# Altium schematic sheet ProjectLOOM.SchDoc(ProjectLOOM)
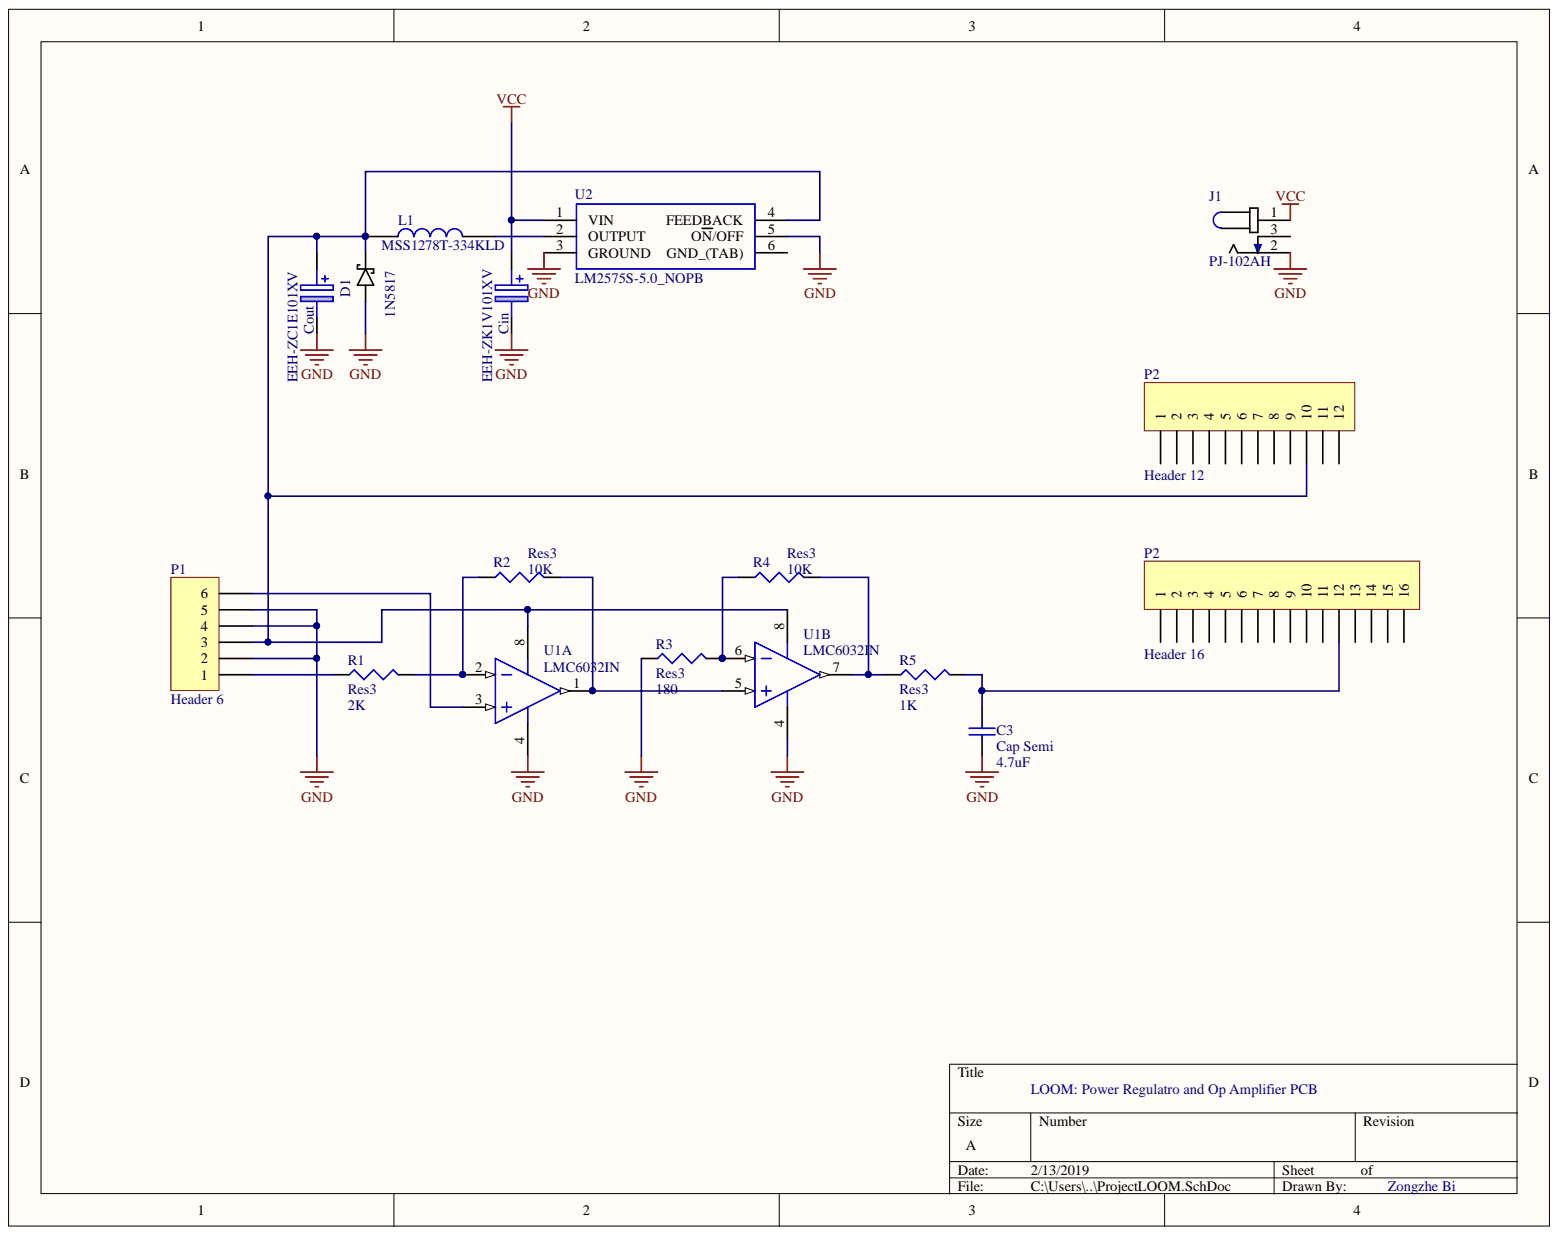
[diagram p0: ProjectLOOM.SchDoc]

## Parts
C3  Cap Semi  value 4.7uF  [C1206]
Cin  EEH-ZK1V101XV  [CAPAE660X800N]
Cout  EEH-ZC1E101XV  [CAPAE660X800N]
D1  1N5817  [DO41-1_1N5817(Primary)]
J1  PJ-102AH  [CUI_PJ-102AH]
L1  MSS1278T-334KLD  [INDPM120120X805N]
P1  Header 6  [HDR1X6]
P2  Header 12  [HDR1X12]
P2  Header 16  [HDR1X16]
R1  Res3  value 2K  [J1-0603]
R2  Res3  value 10K  [J1-0603]
R3  Res3  value 180  [J1-0603]
R4  Res3  value 10K  [J1-0603]
R5  Res3  value 1K  [J1-0603]
U1  LMC6032IN  [N08E]  (parts A,B)
U2  LM2575S-5.0_NOPB  [TO170P1435X465-6N]

## Nets
GND: C3.1 Cin.2 Cout.2 D1.A J1.2 P1.2 P1.4 P1.5 R3.1 U1.4 U2.3(GROUND) U2.5(ON/OFF)
VCC: Cin.1 J1.1 U2.1(VIN)
NetC3_2: C3.2 P2.12 R5.2
NetCout_1: Cout.1 D1.C L1.1 P1.3 P2.10 U1.8 U2.4(FEEDBACK)
NetL1_2: L1.2 U2.2(OUTPUT)
NetP1_1: P1.1 R1.1
NetP1_6: P1.6 U1.3
NetR1_2: R1.2 R2.1 U1.2
NetR2_2: R2.2 U1.1 U1.5
NetR3_2: R3.2 R4.1 U1.6
NetR4_2: R4.2 R5.1 U1.7

Not connected: J1 3; P2 1 2 3 4 5 6 7 8 9 11 13 14 15 16; U2 6(GND_(TAB))

## Library data of the parts
C3 = Cap Semi: Capacitor (Semiconductor SIM Model); Simulation: CAP; Signal Integrity: Cap Semi; Published: 8-Jun-2000; PackageReference: C1206; PackageDescription: Chip Capacitor; Library Name: Miscellaneous Devices.IntLib; LatestRevisionNote: IPC-7351 Footprint Added.; LatestRevisionDate: 29-May-2009; Component Kind: Standard; Publisher: Altium Limited
Cin Cout = EEH-ZC1E101XV: Aluminum Organic Polymer Capacitors 25VDC 100uF 125degC VibeProof AEC-Q200; Manufacturer_Name: Panasonic; Geometry.Height: 8mm; Mouser Price/Stock: https://www.mouser.com/Search/Refine.aspx?Keyword=667-EEH-ZC1E101XV; Mouser Part Number: 667-EEH-ZC1E101XV; Manufacturer_Part_Number: EEH-ZC1E101XV; Library Name: EEH-ZC1E101XV.SchLib; Datasheet Link: https://industrial.panasonic.com/cdbs/www-data/pdf/RDD0000/ABA0000C1234.pdf; Component Kind: Standard
D1 = 1N5817: DIODE, SCHOTTKY, 1A, 20V; Diode Type: Schottky; Voltage, Vrrm: 20V; Current, If AV: 1A; Current, Ifsm: 25A; Voltage, ...; MF: STMicroelectronics; SnapEDA_Link: https://www.snapeda.com/parts/1N5817/STMicroelectronics/view-part/?ref=snap; Price: None; Package: DO-41 Multicomp; MP: 1N5817; Library Name: 1N5817.IntLib; Component Kind: Standard; Availability: Unavailable
J1 = PJ-102AH: 2.0 mm Center Pin, 5.0 A, Right Angle, Through Hole, Tapered Pins, Dc Power Jack Connector; MF: CUI Inc.; SnapEDA_Link: https://www.snapeda.com/parts/PJ-102AH/CUI%20Inc./view-part/?ref=snap; Price: None; Package: None; MP: PJ-102AH; Library Name: PJ-102AH.SchLib; CUI_purchase_URL: https://www.cui.com/product/interconnect/dc-power-connectors/jacks/2.0-mm-center-pin/pj-102ah?utm_source=snapeda.com&utm_medium=referral&utm_campaign=snapedaBOM; Component Kind: Standard; Availability: Unavailable
L1 = MSS1278T-334KLD: COILCRAFT - MSS1278T-334KLD - INDUCTOR, 330UH, 1A, 10%,2.9MHZ, REEL; Geometry.Height: 8.05mm; Mouser Part Number: 994-MSS1278T-334KLD; Manufacturer_Part_Number: MSS1278T-334KLD; Manufacturer_Name: COILCRAFT; Library Name: MSS1278T-334KLD.SchLib; Datasheet Link: https://componentsearchengine.com/Datasheets/1/MSS1278T-334KLD.pdf; Component Kind: Standard
P1 = Header 6: Header, 6-Pin; Signal Integrity: Connector; Library Name: Miscellaneous Connectors.IntLib; LatestRevisionNote: Re-released for DXP Platform.; LatestRevisionDate: 17-Jul-2002; Component Kind: Standard; Publisher: Altium Limited
P2 = Header 12: Header, 12-Pin; Signal Integrity: Connector; Library Name: Miscellaneous Connectors.IntLib; LatestRevisionNote: Re-released for DXP Platform.; LatestRevisionDate: 17-Jul-2002; Component Kind: Standard; Publisher: Altium Limited
P2 = Header 16: Header, 16-Pin; Signal Integrity: Connector; Library Name: Miscellaneous Connectors.IntLib; LatestRevisionNote: Re-released for DXP Platform.; LatestRevisionDate: 17-Jul-2002; Component Kind: Standard; Publisher: Altium Limited
R1-R5 = Res3: Resistor; Simulation: RESISTOR; Signal Integrity: Res; Published: 8-Jun-2000; PackageReference: J1-0603; PackageDescription: Chip Resistor; Library Name: Miscellaneous Devices.IntLib; LatestRevisionNote: IPC-7351 Footprint Added.; LatestRevisionDate: 29-May-2009; Component Kind: Standard; Publisher: Altium Limited
U1 = LMC6032IN: CMOS Dual Operational Amplifier, 8-pin MDIP; Manufacturer: National Semiconductor; PowerWise: N; PackageTopMarking: NSUZXYTTE#*LMC*6032IN; High-Reliability: Y; CompType: Amplifiers; RoHS: N; PinCount: 8; PartNumber: LMC6032IN; PackageVersion: revG, May-2006; PackageReference: N08E; PackageDescription: MDIP, .365 x .250 x .130in, 8 Lead, .100in Pitch; Library Name: National Semiconductor Amplifier.IntLib; DatasheetVersion: Aug-2000; ComponentLink4URL: http://www.national.com/packaging/folders/n08e.html; ComponentLink4Description: N08E Package Page; ComponentLink3URL: http://www.national.com/pf/LM/LMC6032.html; ComponentLink3Description: LMC6032 Web Page; ComponentLink2URL: http://www.national.com/ds/LM/LMC6032.pdf; ComponentLink2Description: LMC6032 Datasheet; ComponentLink1URL: http://www.national.com/; ComponentLink1Description: Manufacturer URL; Component Kind: Standard; Code_JEDEC: MS-001-BA
U2 = LM2575S-5.0_NOPB: SIMPLE SWITCHER 1A Step-Down Voltage Regulator; Manufacturer_Part_Number: LM2575S-5.0/NOPB; Manufacturer_Name: Texas Instruments; Geometry.Height: 4.65mm; Mouser Part Number: 926-LM2575S-5.0/NOPB; Library Name: LM2575S-5.0_NOPB.SchLib; Component Kind: Standard
